# Altium schematic sheet Qadrature FM demodulator.SchDoc("Qadrature FM demodulator")
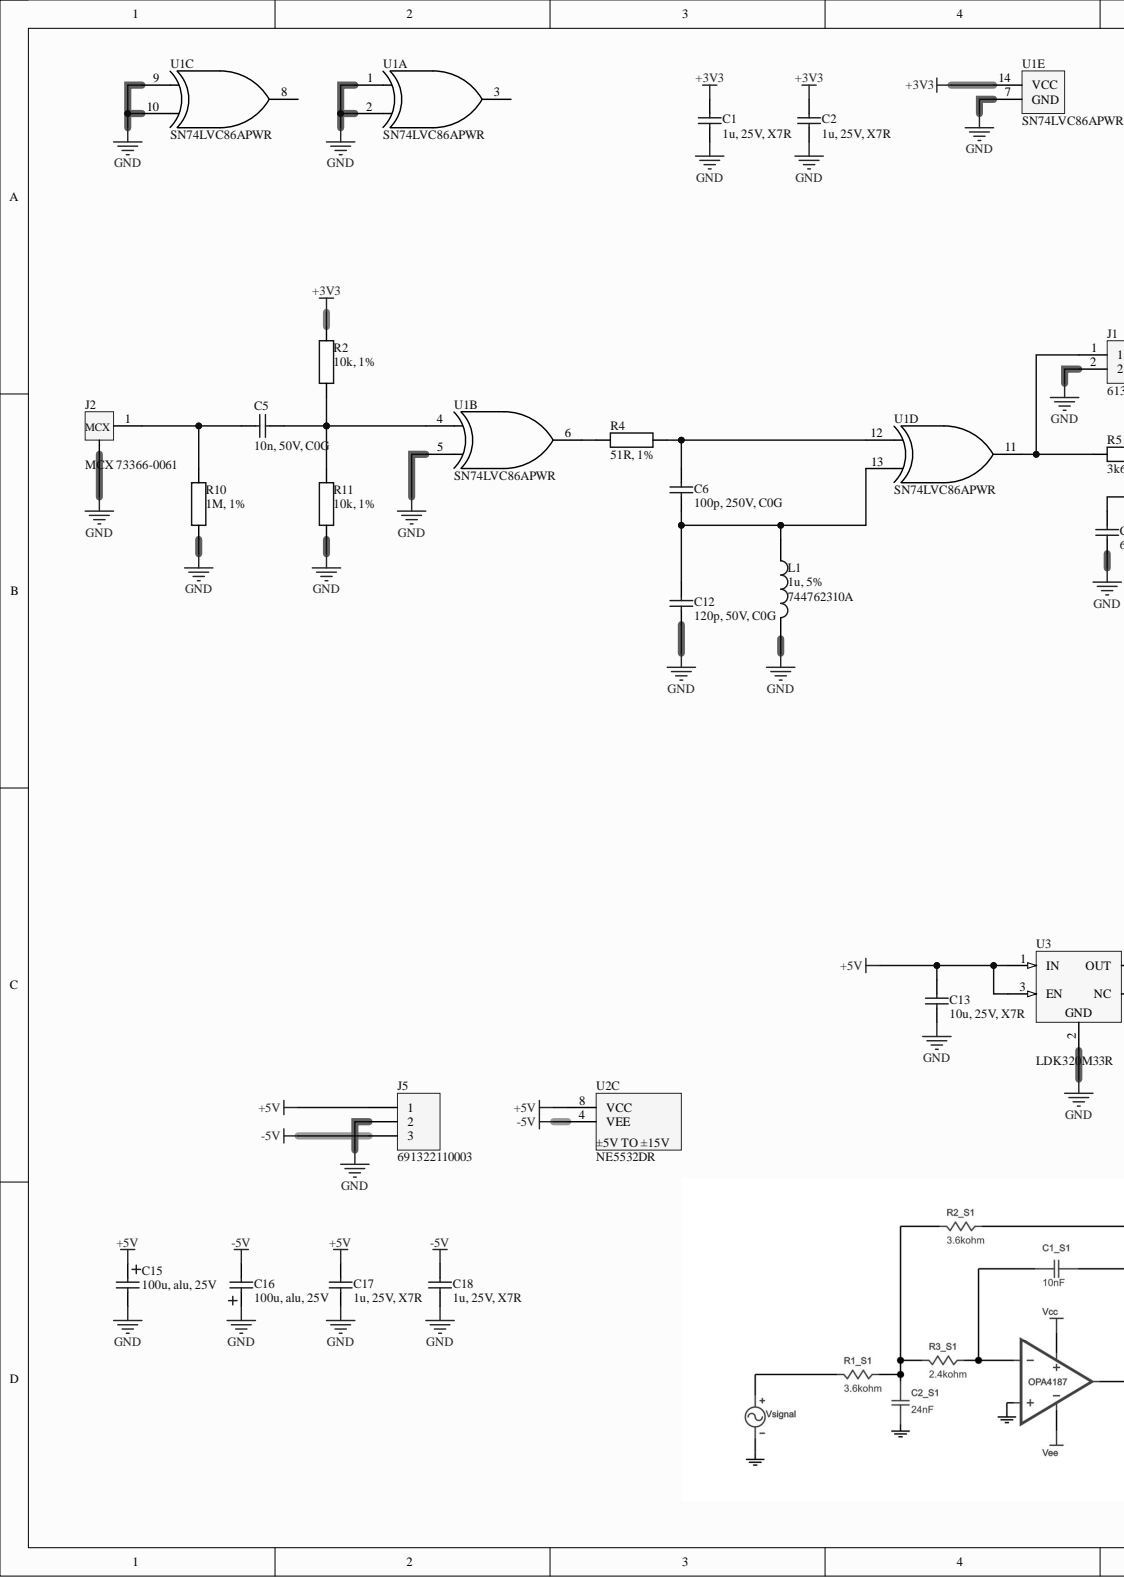
[diagram p0: Qadrature FM demodulator.SchDoc, left]
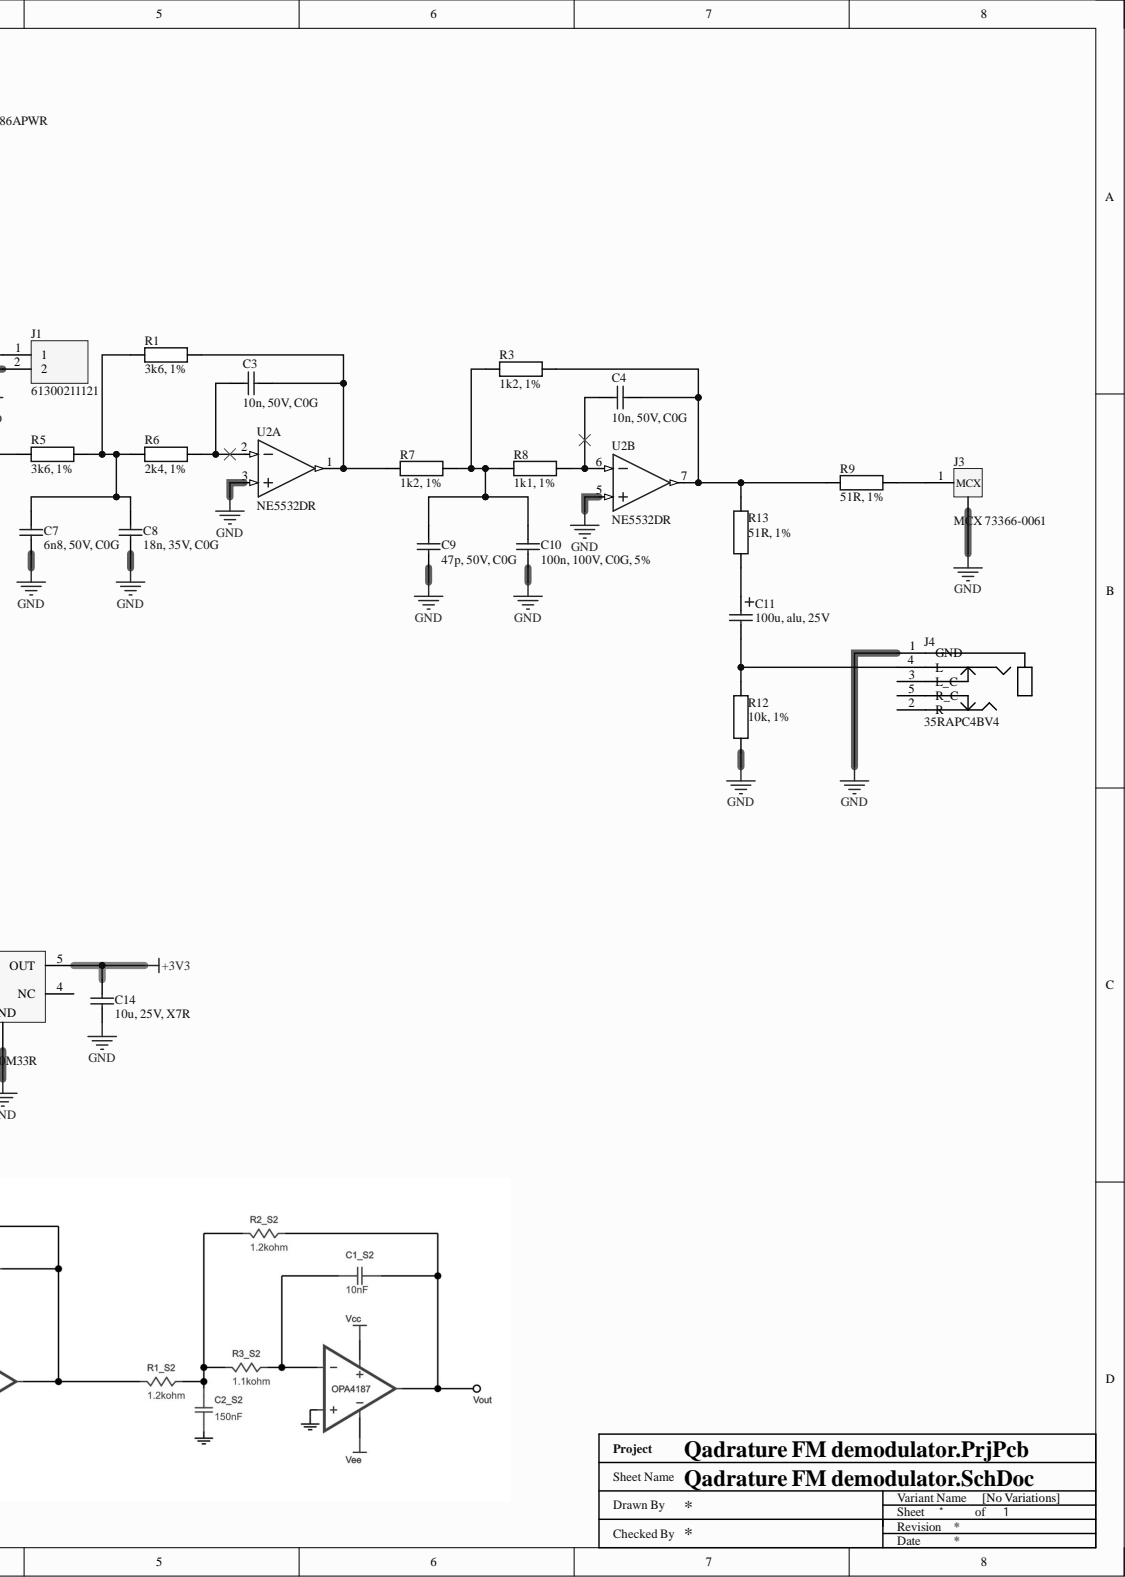
[diagram p1: Qadrature FM demodulator.SchDoc, right]

## Parts
C1  1u, 25V, X7R  [SMD0603]
C2  1u, 25V, X7R  Temperature Coefficient: X7R  [SMD0603]
C3  10n, 50V, C0G  [SMD0603]
C4  10n, 50V, C0G  [SMD0603]
C5  10n, 50V, C0G  [SMD0603]
C6  100p, 250V, C0G  [SMD0603]
C7  6n8, 50V, C0G  [SMD0603]
C8  18n, 35V, C0G  [SMD0603]
C9  47p, 50V, C0G  [SMD0805]
C10  100n, 100V, C0G, 5%  Dielectric: C0G  [SMD1206]
C11  100u, alu, 25V  [WCAP-ATLI_6.3x11x2.5]
C12  120p, 50V, C0G  [SMD0603]
C13  10u, 25V, X7R  [SMD1206]
C14  10u, 25V, X7R  [SMD1206]
C15  100u, alu, 25V  [WCAP-ATLI_6.3x11x2.5]
C16  100u, alu, 25V  Voltage Rating: 25V  [WCAP-ATLI_6.3x11x2.5]
C17  1u, 25V, X7R  Voltage - Rated: 25V  [SMD0603]
C18  1u, 25V, X7R  Temperature Coefficient: X7R  [SMD0603]
J1  61300211121  [Header 2 2.54mm]
J2  MCX 73366-0061  Connector Style: MCX  [73366-0061]
J3  MCX 73366-0061  Connector Style: MCX  [73366-0061]
J4  35RAPC4BV4  [35RAPC4BV4]
J5  691322110003  [691322110003]
L1  1u, 5%  Tolerance: 5%  [WE-KI_1008]
R1  3k6, 1%  Resistance Tolerance: 1%  [SMD0603 - R]
R2  10k, 1%  [SMD0603 - R]
R3  1k2, 1%  [SMD0603 - R]
R4  51R, 1%  [SMD0603 - R]
R5  3k6, 1%  Resistance Tolerance: 1%  [SMD0603 - R]
R6  2k4, 1%  [SMD0603 - R]
R7  1k2, 1%  [SMD0603 - R]
R8  1k1, 1%  [SMD0603 - R]
R9  51R, 1%  [SMD0603 - R]
R10  1M, 1%  [SMD0603 - R]
R11  10k, 1%  [SMD0603 - R]
R12  10k, 1%  [SMD0603 - R]
R13  51R, 1%  [SMD0603 - R]
U1  SN74LVC86APWR  [TSSOP14]  (parts A,B,C,D,E)
U2  NE5532DR  [SOIC08]  (parts A,B,C)
U3  LDK320M33R  [SOT23-5]

## Nets
+3V3: C1.2 C2.2 C14.2 R2.2 U1.14(VCC) U3.5(OUT)
+5V: C13.2 C15.1 C17.2 J5.1 U2.8(VCC) U3.1(IN) U3.3(EN)
-5V: C16.2 C18.2 J5.3 U2.4(VEE)
GND: C1.1 C2.1 C7.1 C8.1 C9.1 C10.1 C12.1 C13.1 C14.1 C15.2 C16.1 C17.1 C18.1 J1.2 J2.2 J3.2 J4.1(GND) J5.2 L1.2 R10.1 R11.1 R12.1 U1.1 U1.2 U1.5 U1.7(GND) U1.9 U1.10 U2.3 U2.5 U3.2
NetC3_1: C3.1 R6.2 U2.2
NetC3_2: C3.2 R1.2 R7.1 U2.1
NetC4_1: C4.1 R8.2 U2.6
NetC4_2: C4.2 R3.2 R9.1 R13.2 U2.7
NetC5_1: C5.1 J2.1 R10.2
NetC5_2: C5.2 R2.1 R11.2 U1.4
NetC6_1: C6.1 C12.2 L1.1 U1.13
NetC6_2: C6.2 R4.2 U1.12
NetC7_2: C7.2 C8.2 R1.1 R5.2 R6.1
NetC9_2: C9.2 C10.2 R3.1 R7.2 R8.1
NetC11_1: C11.1 R13.1
NetC11_2: C11.2 J4.4(L) R12.2
NetJ1_1: J1.1 R5.1 U1.11
NetJ3_1: J3.1 R9.2
NetR4_1: R4.1 U1.6

Not connected: J4 2(R) 3(L_C) 5(R_C); U1 3 8; U3 4(NC)

## Library data of the parts
C1 C2 C17 C18 = CGA3E1X7R1E105K080AC: CAP CER 1UF 25V X7R 0603; Manufacturer: TDK Corporation; Tolerance: ±10%; Applications: Automotive; Capacitance: 1u; Category: Capacitors; Component Kind: Standard; ComponentLink1Description: https://product.tdk.com/info/en/catalog/datasheets/mlcc_automotive_general_en.pdf; ComponentLink1URL: https://product.tdk.com/info/en/catalog/datasheets/mlcc_automotive_general_en.pdf; Failure Rate: -; Features: -; Height - Seated (Max): -; Lead Spacing: -; Lead Style: -; Library Name: SMD0603Cap.IntLib; Manufacturer Part Number: CGA3E1X7R1E105K080AC; Mounting Type: Surface Mount, MLCC; Operating Temperature: -55°C ~ 125°C; Package / Case: 0603 (1608 Metric); Packaging: Cut Tape (CT); Part Status: Active; Pricing 1: 1=0.2, 10=0.135, 100=0.0686, 500=0.04696, 1000=0.04154 (USD); Ratings: AEC-Q200; RoHS: RoHS Compliant; Size / Dimension: 0.063" L x 0.032" W (1.60mm x 0.80mm); Stock 1: 109509; Supplier 1: Mouser; Supplier 2: Farnell; Supplier 3: Digi-Key; Supplier Part Number 1: 810-CGA3E1X7R1E105K; Supplier Part Number 2: 2346962; Supplier Part Number 3: 445-6931-1-ND; Thickness (Max): 0.035" (0.90mm)
  C1: Temperature Coefficient: X7R; Voltage - Rated: 25V
  C2 C18: Voltage - Rated: 25V
  C17: Temperature Coefficient: X7R
C3-C5 = GRM1885C1H103JA01D: Multilayer Ceramic Capacitors MLCC - SMD/SMT 0603 50VDC 10000pF 5% C0G; Brand: Murata Electronics; Capacitance - nF: 10 nF; Class: Class 1; CNHTS: 8532241000; Dielectric: C0G (NP0); TARIC: 8532240000; Type: Chip Multilayer Ceramic Capacitor for General Purpose; Capacitance: 10n; Capacitance - pF: 10000 pF; Case Code - in: 0603; Case Code - mm: 1608; Category: Multilayer Ceramic Capacitors MLCC - SMD/SMT; Component Kind: Standard; ComponentLink1Description: http://www.mouser.com/datasheet/2/281/murata_03052018_GRM_Series_1-1310166.pdf; ComponentLink1URL: http://www.mouser.com/datasheet/2/281/murata_03052018_GRM_Series_1-1310166.pdf; Height: 0.8 mm; HTS Code: 8532240020; Length: 1.6 mm; Library Name: SMD0603Cap.IntLib; Manufacturer: Murata Electronics; Manufacturer Part Number: GRM1885C1H103JA01D; Maximum Operating Temperature: + 125 C; Minimum Operating Temperature: - 55 C; Minimum Order Quantity: 1; Package / Case: 0603 (1608 metric); Packaging: Cut Tape; Pricing 1: 1=0.183, 10=0.129, 100=0.065, 500=0.045, 1000=0.039, 4000=0.030, 8000=0.027, 24000=0.025 (EUR); Product: General Type MLCCs; Product Type: Ceramic Capacitors; Reeling: True; RoHS: RoHS Compliant; RoHS - Mouser: Y; Series: GRM; Standard Pack Qty: 4000; Stock 1: 217291; Subcategory: Capacitors; Supplier 1: Mouser; Supplier 2: Farnell; Supplier Part Number 1: 81-GRM1885C1H103JA1D; Supplier Part Number 2: 2456107; Termination: Standard; Termination Style: SMD/SMT; Tolerance: 5 %; Voltage Rating DC: 50 VDC; Width: 0.8 mm
C6 = 885342006003: CAP CER 100PF 250V C0G/NP0 0603; Manufacturer: Wurth Electronics Inc.; Notification: Current market demand for these product types have resulted in fluctuating and extending lead times. Lead times may differ.; Temperature Coefficient: C0G, NP0; Tolerance: ±5%; Applications: General Purpose; Capacitance: 100p; Category: Capacitors; Component Kind: Standard; ComponentLink1Description: https://katalog.we-online.de/pbs/datasheet/885342006003.pdf; ComponentLink1URL: https://katalog.we-online.de/pbs/datasheet/885342006003.pdf; Failure Rate: -; Features: -; Height - Seated (Max): -; Lead Spacing: -; Lead Style: -; Library Name: SMD0603Cap.IntLib; Manufacturer Part Number: 885342006003; Mounting Type: Surface Mount, MLCC; Operating Temperature: -55°C ~ 125°C; Package / Case: 0603 (1608 Metric); Packaging: Cut Tape (CT); Part Status: Active; Pricing 1: 1=0.1, 10=0.043, 100=0.0385, 500=0.03406 (USD); Ratings: -; RoHS: RoHS Compliant; Size / Dimension: 0.063" L x 0.031" W (1.60mm x 0.80mm); Stock 1: 43; Supplier 1: Digi-Key; Supplier 2: Farnell; Supplier 3: Mouser; Supplier Part Number 1: 732-12167-1-ND; Supplier Part Number 2: 2812519; Supplier Part Number 3: 710-885342006003; Thickness (Max): 0.034" (0.87mm); Voltage - Rated: 250V
C7 = GRM1885C1H682JA01D: Multilayer Ceramic Capacitors MLCC - SMD/SMT 0603 6,800pF 50volts C0G +/-5%; Manufacturer: Murata; Tolerance: 5%; Capacitance: 6n8; Case Code (Imperial): 0603; Case Code (Metric): 1608; Case/Package: 0603; Component Kind: Standard; ComponentLink1Description: https://datasheet.ciiva.com/29537/partnumbering-e-01-29537912.pdf?src-supplier=Digi-Key; ComponentLink1URL: https://datasheet.ciiva.com/29537/partnumbering-e-01-29537912.pdf?src-supplier=Digi-Key; Depth: 0.8mm; Dielectric: C0G; Height: 0.8mm; Lead Free: Lead Free; Length: 1.6mm; Library Name: SMD0603Cap.IntLib; Manufacturer Part Number: GRM1885C1H682JA01D; Max Operating Temperature: 125°C; Min Operating Temperature: -55°C; Mount: Surface Mount; Package Quantity: 4000; Packaging: Tape and Reel; Pricing 1: 1 = 0.20000, 10 = 0.13500, 50 = 0.13500, 100 = 0.06800, 1000 = 0.04100, 10000 = 0.02900 (USD); RoHS: Yes; Stock 1: 117152; Supplier 1: Mouser; Supplier 2: Digi-Key; Supplier 3: Arrow; Supplier Part Number 1: 81-GRM1885C1H682JA1D; Supplier Part Number 2: 490-11477-1-ND; Supplier Part Number 3: GRM1885C1H682JA01D; Termination: SMD/SMT; Thickness: 0.035inch; Voltage Rating: 50V; Voltage Rating (DC): 50V; Width: 0.031inch
C8 = C1608C0G1V183J080AC: Cap Ceramic 0.018uF 35V C0G 5% Pad SMD 0603 125C T/R; Manufacturer: TDK; Capacitance: 18n; Case Code (Imperial): 0603; Case Code (Metric): 1608; Case/Package: 0603; Category: Ceramic Capacitors; Component Kind: Standard; ComponentLink1Description: https://product.tdk.com/info/en/catalog/spec/mlccspec_commercial_general_midvoltage_en.pdf?src-supplier=Digi-Key; ComponentLink1URL: https://product.tdk.com/info/en/catalog/spec/mlccspec_commercial_general_midvoltage_en.pdf?src-supplier=Digi-Key; Dielectric Material: Ceramic; Lead Free: Lead Free; Length: 0.063inch; Library Name: SMD0603Cap.IntLib; Manufacturer Part Number: C1608C0G1V183J080AC; Max Operating Temperature: 125°C; Min Operating Temperature: -55°C; Mount: Surface Mount; Packaging: Tape and Reel; Pricing 1: 1=0.37000, 10=0.16800, 50=0.16800, 100=0.14300, 1000=0.08800, 10000=0.06600 (USD); RoHS: Yes; RoHS Compliant: Yes; Stock 1: 3185; Supplier 1: Mouser; Supplier 2: Farnell; Supplier 3: Digi-Key; Supplier 4: Newark; Supplier Part Number 1: 810-C1608C0G1V183JAC; Supplier Part Number 2: 3416084; Supplier Part Number 3: 445-174558-1-ND; Supplier Part Number 4: 71AH3230; Thickness: 0.035inch; Tolerance: 5%; Voltage Rating: 35V; Voltage Rating (DC): 35V; Width: 0.031inch
C9 = MC0805N470J500CT: MULTICOMP - MC0805N470J500CT - CAP, MLCC, C0G/NP0, 47PF, 50V, 0805; Capacitance: 47; Capacitance Tolerance: ± 5%; Ceramic Capacitor Case: 0805 [2012 Metric]; Product Range: MC Series; SVHC: No SVHC (07-Jul-2017)<!--FANDB--><div class="features-and-benefits-container"><div class="features-and-benefits-desc-container"><span class="features-and-benefits-container-desc">SMD Multilayer Ceramic Capacitor, 0805 [2012 Metric], 47 pF, 50 V, ± 5%, C0G / NP0, MC Series</span></div><div class="features-and-benefits-description"><span class="features-benefits-value">The Multicomp MC series is general purpose MLCC capacitors with C0G (NP0) dielectric material which provides high electrical precision, stability and reliability. This MLCC capacitor consists of a conducting material and electrodes with high density and high efficiency ceramic condensers.</span></div><div class="features-and-benefits-list"><ul class="features-and-benefits-list-list"><li class="features-and-benefits-list-item">Small size with higher capacitance values</li><li class="features-and-benefits-list-item">0201, 0402, 0603, 0805, 1206, 1210, 1812 case sizes available</li><li class="features-and-benefits-list-item">Capacitance value ranging from 0.1pF to 0.1?F</li><li class="features-and-benefits-list-item">Capacitor with lead free termination (pure Tin)</li><li class="features-and-benefits-list-item">High electrical precision, stability and reliability</li><li class="features-and-benefits-list-item">Rated voltage of 10V, 16V, 25V, 50V, 100V</li><li class="features-and-benefits-list-item">Operating temperature of -55°C to +125°C</li><li class="features-and-benefits-list-item">Temperature coefficient (TCR) of ±30; Voltage Rating: 50; Automotive Qualification Standard: -; Component Kind: Standard; ComponentLink1Description: http://www.farnell.com/datasheets/1901289.pdf; ComponentLink1URL: http://www.farnell.com/datasheets/1901289.pdf; Dielectric Characteristic: C0G / NP0; Library Name: SMD0805Cap.IntLib; Manufacturer: MULTICOMP; Manufacturer Part Number: MC0805N470J500CT; MSL: -; Operating Temperature Max: 125; Operating Temperature Min: -55; Packaging: Cut Tape; Pricing 1: 10=0.0144, 100=0.0117, 500=0.0083, 1000=0.0072, 2000=0.0057 (GBP); RoHS: YES; Stock 1: 26554; Supplier 1: Farnell; Supplier Part Number 1: 1759199
C10 = GRM31C5C2A104JA01L: CAP CER 0.1UF 100V C0G/NP0 1206; Manufacturer: Murata Electronics North America; Notification: Current market demand for these product types have resulted in fluctuating and extending lead times. Lead times may differ.; Temperature Coefficient: C0G, NP0; Applications: General Purpose; Capacitance: 100n; Category: Capacitors; Component Kind: Standard; ComponentLink1Description: http://search.murata.co.jp/Ceramy/image/img/A01X/G101/ENG/GRM31C5C2A104JA01-01.pdf; ComponentLink1URL: http://search.murata.co.jp/Ceramy/image/img/A01X/G101/ENG/GRM31C5C2A104JA01-01.pdf; Failure Rate: -; Features: -; Height - Seated (Max): -; Lead Spacing: -; Lead Style: -; Library Name: SMD1206Cap.IntLib; Manufacturer Part Number: GRM31C5C2A104JA01L; Mounting Type: Surface Mount, MLCC; Operating Temperature: -55°C ~ 125°C; Package / Case: 1206 (3216 Metric); Packaging: Cut Tape (CT); Part Status: Active; Pricing 1: 1=0.82, 10=0.581, 100=0.3823, 500=0.2832, 1000=0.24072 (USD); Ratings: -; RoHS: RoHS Compliant; Size / Dimension: 0.126" L x 0.063" W (3.20mm x 1.60mm); Stock 1: 1640; Supplier 1: Mouser; Supplier 2: Digi-Key; Supplier Part Number 1: 81-GRM31C5C2A104JA1L; Supplier Part Number 2: 490-16315-1-ND; Thickness (Max): 0.071" (1.80mm); Tolerance: ±5%; Voltage - Rated: 100V
C11 C15 C16 = 860010473007: CAP 100 UF 20% 25 V; Manufacturer: Wurth Electronics; Capacitance: 100uF; Life (Hours): 2000Hour; Material: Aluminium; Polarity: Polar; Ripple Current: 209mA; Case/Package: Radial; Category: Aluminum Electrolytic Capacitors; Component Kind: Standard; ComponentLink1Description: https://datasheet.ciiva.com/48693/001280151-da-01-en-wcap-atg8-alu-elec-caps-860010473007-48693591.pdf?src-supplier=Conrad; ComponentLink1URL: https://datasheet.ciiva.com/48693/001280151-da-01-en-wcap-atg8-alu-elec-caps-860010473007-48693591.pdf?src-supplier=Conrad; Diameter: 6.3mm; Height: 11mm; Height - Seated (Max): 0.492inch; Lead Pitch: 0.098inch; Library Name: Capacitors.IntLib; Manufacturer Part Number: 860010473007; Max Operating Temperature: 85°C; Min Operating Temperature: -40°C; Mount: Through Hole; Package Quantity: 1500; Packaging: Tape and Reel; Pricing 1: 1=0.14000, 10=0.13400, 25=0.13400, 50=0.11200, 100=0.09800, 250=0.08700 (USD); REACH SVHC: Unknown; RoHS: Yes; Stock 1: 1494; Supplier 1: Mouser; Supplier 2: Farnell; Supplier 3: Digi-Key; Supplier Part Number 1: 710-860010473007; Supplier Part Number 2: 2465675; Supplier Part Number 3: 732-8630-1-ND; Tolerance: 20%; Voltage Rating (DC): 25V
  C11 C15: Voltage Rating: 25V
C12 = CC0603JRNPO9BN121: Surface Mount Ceramic Multilayer Capacitor 120pF 5% C0G 50V 0603 Paper T/R; Manufacturer: Yageo; Insulation Resistance: 100GR; Capacitance: 120pF; Case Code (Imperial): 0603; Case Code (Metric): 1608; Case/Package: 0603; Category: Ceramic Capacitors; Component Kind: Standard; ComponentLink1Description: https://datasheet.ciiva.com/26862/getdatasheetpartid-137962-26862760.pdf?src-supplier=Verical; ComponentLink1URL: https://datasheet.ciiva.com/26862/getdatasheetpartid-137962-26862760.pdf?src-supplier=Verical; ComponentLink2Description: https://datasheet.ciiva.com/144/mlccorderingcode-144699.pdf?src-supplier=Digi-Key; ComponentLink2URL: https://datasheet.ciiva.com/144/mlccorderingcode-144699.pdf?src-supplier=Digi-Key; ComponentLink3Description: https://datasheet.ciiva.com/8033/1443161-8033719.pdf?src-supplier=Element14; ComponentLink3URL: https://datasheet.ciiva.com/8033/1443161-8033719.pdf?src-supplier=Element14; ComponentLink4Description: https://datasheet.ciiva.com/17437/upy-gp-np0-16v-to-50v-8-17437015.pdf?src-supplier=Avnet+Europe; ComponentLink4URL: https://datasheet.ciiva.com/17437/upy-gp-np0-16v-to-50v-8-17437015.pdf?src-supplier=Avnet+Europe; ComponentLink5Description: https://datasheet.ciiva.com/8033/1443161-8033720.pdf?src-supplier=Element14; ComponentLink5URL: https://datasheet.ciiva.com/8033/1443161-8033720.pdf?src-supplier=Element14; ComponentLink6Description: https://datasheet.ciiva.com/144/mlccorderingcode-144697.pdf?src-supplier=Digi-Key; ComponentLink6URL: https://datasheet.ciiva.com/144/mlccorderingcode-144697.pdf?src-supplier=Digi-Key; ComponentLink7Description: https://datasheet.ciiva.com/11465/upy-gp-np0-16v-to-50v-8-11465007.pdf?src-supplier=Avnet; ComponentLink7URL: https://datasheet.ciiva.com/11465/upy-gp-np0-16v-to-50v-8-11465007.pdf?src-supplier=Avnet; ComponentLink8Description: https://datasheet.ciiva.com/144/mlccorderingcode-144698.pdf?src-supplier=Digi-Key; ComponentLink8URL: https://datasheet.ciiva.com/144/mlccorderingcode-144698.pdf?src-supplier=Digi-Key; ComponentLink9Description: https://datasheet.ciiva.com/15381/upy-gp-np0-16v-to-50v-8-15381898.pdf?src-supplier=Mouser; ComponentLink9URL: https://datasheet.ciiva.com/15381/upy-gp-np0-16v-to-50v-8-15381898.pdf?src-supplier=Mouser; Depth: 0.8mm; Dielectric: C0G; Dielectric Material: Ceramic; Height: 0.8mm; Lead Free: Lead Free; Length: 1.6mm; Library Name: 0603Capacitors.SchLib; Manufacturer Part Number: CC0603JRNPO9BN121; Max Operating Temperature: 125°C; Min Operating Temperature: -55°C; Mount: Surface Mount; Package Quantity: 1; Packaging: Tape and Reel; Pricing 1: 1=0.10000, 10=0.06400, 50=0.06400, 100=0.02900, 1000=0.01700, 10000=0.01100 (USD); Radiation Hardening: No; REACH SVHC: Unknown; Resistor Type: Ceramic; RoHS: Yes; RoHS Compliant: Yes; Series: CC; Stock 1: 20714; Supplier 1: Mouser; Supplier 2: Farnell; Supplier 3: Digi-Key; Supplier Part Number 1: 603-CC603JRNPO9BN121; Supplier Part Number 2: 722091; Supplier Part Number 3: 311-1070-1-ND; Termination: SMD/SMT; Thickness: 0.035inch; Tolerance: 5%; Voltage Rating: 50V; Voltage Rating (DC): 50V; Width: 0.031inch
C13 C14 = GRM31CR71E106KA12L: MURATA - GRM31CR71E106KA12L - CAP, MLCC, X7R, 10UF, 25V, 1206; Capacitance: 10u; Component Kind: Standard; ComponentLink1Description: http://www.farnell.com/datasheets/2048746.pdf; ComponentLink1URL: http://www.farnell.com/datasheets/2048746.pdf; Dielectric: X7R; Library Name: SMD1206Cap.IntLib; Manufacturer: MURATA; Manufacturer Part Number: GRM31CR71E106KA12L; Pricing 1: 5=0.278, 50=0.185, 250=0.139, 500=0.134, 1000=0.128 (GBP); RoHS: YES; Stock 1: 8848; Supplier 1: Mouser; Supplier 2: Farnell; Supplier 3: Digi-Key; Supplier Part Number 1: 81-GRM31CR71E106KA12; Supplier Part Number 2: 1828837; Supplier Part Number 3: 490-6518-1-ND
J1 = 61300211121: CONN HEADER 2 POS 2.54; Manufacturer: Wurth Electronics Inc.; Contact Length - Mating: 0.236" (6.00mm); Contact Length - Post: 0.118" (3.00mm); Current Rating: 3A; Material Flammability Rating: UL94 V-0; Number of Positions: 2; Number of Positions Loaded: All; Overall Contact Length: 0.454" (11.54mm); Pitch - Mating: 0.100" (2.54mm); Shrouding: Unshrouded; Style: Board to Board or Cable; Voltage Rating: 250V; Applications: -; Category: Connectors, Interconnects; Component Kind: Standard; ComponentLink1Description: https://katalog.we-online.de/em/datasheet/6130xx11121.pdf; ComponentLink1URL: https://katalog.we-online.de/em/datasheet/6130xx11121.pdf; Connector Type: Header; Contact Finish - Mating: Gold; Contact Finish - Post: -; Contact Finish Thickness - Mating: -; Contact Finish Thickness - Post: -; Contact Material: Copper Alloy; Contact Shape: Square; Contact Type: Male Pin; Fastening Type: Push-Pull; Features: -; Ingress Protection: -; Insulation Color: Black; Insulation Height: 0.100" (2.54mm); Insulation Material: Polybutylene Terephthalate (PBT); Library Name: Connectors.IntLib; Manufacturer Part Number: 61300211121; Mated Stacking Heights: -; Mounting Type: Through Hole; Number of Rows: 1; Operating Temperature: -40°C ~ 125°C; Packaging: Bulk; Part Status: Active; Pricing 1: 1=0.13, 10=0.106, 25=0.088, 50=0.074, 100=0.067, 1000=0.061 (USD); RoHS: RoHS Compliant; Row Spacing - Mating: -; Stock 1: 164911; Supplier 1: Mouser; Supplier 2: Farnell; Supplier 3: Digi-Key; Supplier Part Number 1: 710-61300211121; Supplier Part Number 2: 2356153; Supplier Part Number 3: 732-5315-ND; Termination: Solder
J2 J3 = 73366-0061: CONN MCX JACK STR 50 OHM PCB; Manufacturer: Molex, LLC; Manufacturer Part Number: 0733660061; Body Finish: Gold; Body Material: Brass; Center Contact Material: Beryllium Copper; Connector Type: Jack, Female Socket; Contact Termination: Solder; Dielectric Material: Polytetrafluoroethylene (PTFE); Impedance: 50 Ohm; Mating Cycles: 500; Cable Group: -; Category: Connectors, Interconnects; Center Contact Plating: Gold; Component Kind: Standard; ComponentLink1Description: https://www.molex.com/pdm_docs/sd/733660061_sd.pdf; ComponentLink1URL: https://www.molex.com/pdm_docs/sd/733660061_sd.pdf; Fastening Type: Snap-On; Features: -; Housing Color: Gold; Includes: -; Ingress Protection: -; Insertion Loss: -; Library Name: Connectors.IntLib; Mounting Feature: -; Mounting Type: Through Hole; Operating Temperature: -55°C ~ 155°C; Packaging: Tray; Part Status: Active; Pricing 1: 1=1.95, 10=1.765, 25=1.6572, 50=1.5854, 100=1.5133, 250=1.36916, 500=1.26106, 1000=1.0809, 2500=1.00884 (USD); RoHS: RoHS Compliant; Shield Termination: Solder; Stock 1: 39394; Supplier 1: Mouser; Supplier 2: Digi-Key; Supplier 3: Farnell; Supplier Part Number 1: 538-73366-0061; Supplier Part Number 2: WM5541-ND; Supplier Part Number 3: 1909245
J4 = 35RAPC4BV4: SWITCHCRAFT - 35RAPC4BV4 - CONNECTOR, RCA/PHONO, JACK, 3WAY; Connector Body Material: Plastic Body; Connector Colour: Black; Connector Mounting: PCB Mount; Contact Termination: Solder<!--FANDB--><div class="features-and-benefits-container"><div class="features-and-benefits-description"><span class="features-benefits-value">The 35RAPC4BV4 is a 3.5mm Mono and Stereo PC Board Vertical-mount Jack with black PBT+15% glass filled housing, nickel-plated brass bushing, tin-plated phosphor bronze springs and a clear polycarbonate cover. The compact design and an easy of installation onto a PCB make this an ideal solution.</span></div><div class="features-and-benefits-list"><ul class="features-and-benefits-list-list"><li class="features-and-benefits-list-item">5000 cycles Life</li><li class="features-and-benefits-list-item">50; No. of Contacts: 3; Pin Diameter: 3.5; SVHC: No SVHC (15-Jun-2015); Component Kind: Standard; ComponentLink1Description: http://www.farnell.com/datasheets/921436.pdf; ComponentLink1URL: http://www.farnell.com/datasheets/921436.pdf; Contact Plating: Tin Plated Contacts; Gender: Jack; Library Name: Connectors.IntLib; Manufacturer: SWITCHCRAFT; Manufacturer Part Number: 35RAPC4BV4; Pricing 1: 1=1.28, 25=1.11, 50=1.06, 100=1.01, 250=0.975, 500=0.939 (GBP); Product Range: -; RoHS: YES; Stock 1: 216; Supplier 1: Farnell; Supplier 2: Digi-Key; Supplier Part Number 1: 2293877; Supplier Part Number 2: SC1461-ND
J5 = 691322110003: WR-TBL Serie 3221 - 3.50 mm Horizontal PCB Header, 03p; Pitch: 3.5 mm; Component Kind: Standard; ComponentLink1Description: Manufacturer; ComponentLink1URL: http://www.we-online.de; ComponentLink2Description: Datasheet; ComponentLink2URL: http://katalog.we-online.de/em/datasheet/6913221100xx.pdf; Library Name: Connectors.IntLib; Part Number: 691322110003; Pin Number: 03p; Published Date: Jan 2017; Supplier 1: Digi-Key; Supplier 2: Farnell; Supplier Part Number 1: 732-2770-ND; Supplier Part Number 2: 1841316; Publisher: Wurth Elektronik
L1 = 744762310A: Fixed Ind 1UH 120MA 3.3 Ohm SMD; Manufacturer: Wurth Electronics; Core Material: Ceramic; DC Resistance (DCR): 3.3R; Q Factor: 35; Self Resonant Frequency: 310MHz; Shielding: Unshielded; Test Frequency: 25MHz; Case Code (Imperial): 1008; Case Code (Metric): 2520; Case/Package: 1008; Category: Fixed Inductors; Component Kind: Standard; ComponentLink1Description: https://datasheet.ciiva.com/1049/744762310a-1049271.pdf?src-supplier=Digi-Key; ComponentLink1URL: https://datasheet.ciiva.com/1049/744762310a-1049271.pdf?src-supplier=Digi-Key; ComponentLink2Description: https://datasheet.ciiva.com/4978/744762310a-69476-4978731.pdf?src-supplier=Mouser; ComponentLink2URL: https://datasheet.ciiva.com/4978/744762310a-69476-4978731.pdf?src-supplier=Mouser; ComponentLink3Description: https://datasheet.ciiva.com/1049/744762310a-1049272.pdf?src-supplier=Digi-Key; ComponentLink3URL: https://datasheet.ciiva.com/1049/744762310a-1049272.pdf?src-supplier=Digi-Key; ComponentLink4Description: https://datasheet.ciiva.com/1049/744762310a-1049273.pdf?src-supplier=Digi-Key; ComponentLink4URL: https://datasheet.ciiva.com/1049/744762310a-1049273.pdf?src-supplier=Digi-Key; Current Rating: 120mA; Height: 0.039inch; Height - Seated (Max): 0.075inch; Inductance: 1uH; Length: 0.102inch; Library Name: Inductors.SchLib; Manufacturer Part Number: 744762310A; Max DC Current: 120mA; Max Operating Temperature: 125°C; Min Operating Temperature: -40°C; Mount: Surface Mount; Package Quantity: 2000; Packaging: Tape and Reel; Pricing 1: 1=0.41000, 10=0.38200, 50=0.35700, 100=0.33000, 1000=0.30300, 10000=0.25600 (USD); Resistor Type: Wirewound,Ceramic; RoHS: Yes; RoHS Compliant: Yes; Series: WE-KI; Stock 1: 1904; Supplier 1: Mouser; Supplier 2: Farnell; Supplier 3: Digi-Key; Supplier Part Number 1: 710-744762310A; Supplier Part Number 2: 3517053; Supplier Part Number 3: 732-1832-1-ND; Termination: SMD/SMT; Width: 0.083inch
R1 R5 = RC0603FR-073K6L: RES SMD 3.6K OHM 1% 1/10W 0603; Manufacturer: Yageo; Max Power Dissipation: 100mW; Temperature Coefficient: 100ppm/°C; Voltage Rating: 50V; Working Voltage: 75V; Case Code (Imperial): 0603; Case Code (Metric): 1608; Case/Package: 0603; Category: Chip SMD Resistors; Component Kind: Standard; ComponentLink1Description: https://datasheet.ciiva.com/2455/pyu-r-int-thick-7-2455116.pdf?src-supplier=Digi-Key; ComponentLink1URL: https://datasheet.ciiva.com/2455/pyu-r-int-thick-7-2455116.pdf?src-supplier=Digi-Key; ComponentLink2Description: https://datasheet.ciiva.com/2455/pyu-r-int-thick-7-2455117.pdf?src-supplier=Digi-Key; ComponentLink2URL: https://datasheet.ciiva.com/2455/pyu-r-int-thick-7-2455117.pdf?src-supplier=Digi-Key; ComponentLink3Description: https://datasheet.ciiva.com/2455/pyu-r-int-thick-7-2455118.pdf?src-supplier=Digi-Key; ComponentLink3URL: https://datasheet.ciiva.com/2455/pyu-r-int-thick-7-2455118.pdf?src-supplier=Digi-Key; Features: Moisture Resistant; Height: 0.022inch; Lead Free: Lead Free; Length: 0.063inch; Library Name: SMD0603Res.IntLib; Manufacturer Part Number: RC0603FR-073K6L; Max Operating Temperature: 155°C; Min Operating Temperature: -55°C; Number of Pins: 2; Number of Terminations: 2; Package Quantity: 1; Packaging: Tape and Reel; Power Rating: 100mW; Pricing 1: 1=0,10000, 10=0,01800, 50=0,01800, 100=0,00600, 1000=0,00500, 10000=0,00300 (USD); REACH SVHC: Unknown; Resistance: 3k6; Resistor Type: Thick Film; RoHS: Yes; RoHS Compliant: Yes; Series: RC; Stock 1: 130231; Supplier 1: Mouser; Supplier 2: Farnell; Supplier 3: Digi-Key; Supplier Part Number 1: 603-RC0603FR-073K6L; Supplier Part Number 2: 3495832; Supplier Part Number 3: 311-3.60KHRCT-ND; Technology: METAL OXIDE FILM; Termination: SMD/SMT; Tolerance: 1%; Voltage Rating (DC): 50V; Width: 0.8mm
R2 R11 R12 = RC0603FR-0710KL: YAGEO (PHYCOMP) - RC0603FR-0710KL - RES, THICK FILM, 10K, 1%, 0.1W, 0603; Power Rating: 100; Product Range: RC Series; Resistor Case Style: 0603 [1608 Metric]; SVHC: No SVHC (20-Jun-2016); Temperature Coefficient: ± 100; Voltage Rating: 50; Automotive Qualification Standard: -; Component Kind: Standard; ComponentLink1Description: http://past.yageo.com/pdf/PYu-R_Packing_6.pdf; ComponentLink1URL: http://past.yageo.com/pdf/PYu-R_Packing_6.pdf; ComponentLink2Description: http://www.farnell.com/datasheets/2167052.pdf; ComponentLink2URL: http://www.farnell.com/datasheets/2167052.pdf; Library Name: SMD0603Res.IntLib; Manufacturer: YAGEO (PHYCOMP); Manufacturer Part Number: RC0603FR-0710KL; MSL: MSL 1 - Unlimited<!--FANDB--><div class="features-and-benefits-container"><div class="features-and-benefits-desc-container"><span class="features-and-benefits-container-desc">SMD Chip Resistor, Thick Film, 10 kohm, 50 V, 0603 [1608 Metric], 100 mW, ± 1; Packaging: Tape & Reel; Pricing 1: 5000=0.0025, 25000=0.0014, 50000=0.0013 (GBP); Resistance: 10k; Resistance Tolerance: ± 1%; Resistor Element Material: Thick Film; RoHS: YES; Stock 1: 9995; Supplier 1: Mouser; Supplier 2: Farnell; Supplier 3: Digi-Key; Supplier Part Number 1: 603-RC0603FR-0710KL; Supplier Part Number 2: 2421850; Supplier Part Number 3: 311-10.0KHRCT-ND
R3 R7 = WR06X1201FTL: WALSIN - WR06X1201FTL - RESISTOR, THICK FILM, 1K2, 1%, 75V, 0603; Power Rating: 100; Product Range: WR06 Series; Resistor Case Style: 0603 [1608 Metric]; Temperature Coefficient: ± 100; Voltage Rating: 75; Automotive Qualification Standard: -; Component Kind: Standard; ComponentLink1Description: http://www.farnell.com/datasheets/1960319.pdf; ComponentLink1URL: http://www.farnell.com/datasheets/1960319.pdf; Library Name: SMD0603Res.IntLib; Manufacturer: WALSIN; Manufacturer Part Number: WR06X1201FTL; MSL: MSL 2 - 1 year<!--FANDB--><div class="features-and-benefits-container"><div class="features-and-benefits-desc-container"><span class="features-and-benefits-container-desc">SMD Chip Resistor, 1.2 kohm, 75 V, 0603 [1608 Metric], 100 mW, ± 1%, WR06 Series</span></div><div class="features-and-benefits-description"><span class="features-benefits-value">The WR06 series resistors from Walsin are general purpose thick film chip resistors. These resistors are constructed in a high grade ceramic body (aluminium oxide).</span></div><div class="features-and-benefits-list"><ul class="features-and-benefits-list-list"><li class="features-and-benefits-list-item">Resistance range includes E24 and E96 decade values from 1ohm to 10Mohm</li><li class="features-and-benefits-list-item">EIA 0603 case size</li><li class="features-and-benefits-list-item">Available in ?±100ppm/°C and -200ppm/°C to +400ppm/°C TCR values</li><li class="features-and-benefits-list-item">Available in ±1% and ±5% tolerance</li><li class="features-and-benefits-list-item">High reliability and stability</li><li class="features-and-benefits-list-item">Higher component and equipment reliability</li><li class="features-and-benefits-list-item">Lower assembly costs</li><li class="features-and-benefits-list-item">Reduced size of final equipment</li><li class="features-and-benefits-list-item">Continuous working voltage is 75V and rated power is 100; Packaging: Cut Tape; Pricing 1: 10=0.0134, 100=0.0045, 500=0.0027, 1000=0.0019, 2500=0.0015 (GBP); Resistance: 1k2; Resistance Tolerance: ± 1%; Resistor Element Material: Thick Film; RoHS: YES; Stock 1: 8072; Supplier 1: Farnell; Supplier 2: Newark; Supplier Part Number 1: 2502404; Supplier Part Number 2: 58Y7264
R4 R9 R13 = CRCW060351R0FKEA: RES SMD 51 OHM 1% 1/10W 0603; Manufacturer: Vishay Dale; Power (Watts): 0.1W, 1/10W; Temperature Coefficient: ±100ppm/°C; Category: Resistors; Component Kind: Standard; ComponentLink1Description: http://www.vishay.com/docs/20035/dcrcwe3.pdf; ComponentLink1URL: http://www.vishay.com/docs/20035/dcrcwe3.pdf; Composition: Thick Film; Failure Rate: -; Features: Automotive AEC-Q200; Height - Seated (Max): 0.020" (0.50mm); Library Name: SMD0603Res.IntLib; Manufacturer Part Number: CRCW060351R0FKEA; Operating Temperature: -55°C ~ 155°C; Package / Case: 0603 (1608 Metric); Packaging: Cut Tape (CT); Part Status: Active; Pricing 1: 1=0.1, 10=0.042, 100=0.017, 1000=0.00764, 2500=0.00663 (USD); Resistance: 51R; RoHS: RoHS Compliant; Size / Dimension: 0.063" L x 0.033" W (1.60mm x 0.85mm); Stock 1: 21691; Supplier 1: Mouser; Supplier 2: Farnell; Supplier 3: Digi-Key; Supplier Device Package: 0603; Supplier Part Number 1: 71-CRCW0603-51-E3; Supplier Part Number 2: 1652889; Supplier Part Number 3: 541-51.0HCT-ND; Tolerance: ±1%
R6 = RC0603FR-072K4L: RES SMD 2.4K OHM 1% 1/10W 0603; Manufacturer: Yageo; Power (Watts): 0.1W, 1/10W; Temperature Coefficient: ±100ppm/°C; Category: Resistors; Component Kind: Standard; ComponentLink1Description: http://www.yageo.com/documents/recent/PYu-RC_Group_51_RoHS_L_8.pdf; ComponentLink1URL: http://www.yageo.com/documents/recent/PYu-RC_Group_51_RoHS_L_8.pdf; Composition: Thick Film; Failure Rate: -; Features: Moisture Resistant; Height - Seated (Max): 0.022" (0.55mm); Library Name: SMD0603Res.IntLib; Manufacturer Part Number: RC0603FR-072K4L; Operating Temperature: -55°C ~ 155°C; Package / Case: 0603 (1608 Metric); Packaging: Cut Tape (CT); Part Status: Active; Pricing 1: 1=0.1, 10=0.015, 100=0.006, 1000=0.00268, 2500=0.00233 (USD); Resistance: 2k4; RoHS: RoHS Compliant; Size / Dimension: 0.063" L x 0.032" W (1.60mm x 0.80mm); Stock 1: 577810; Supplier 1: Mouser; Supplier 2: Farnell; Supplier 3: Digi-Key; Supplier Device Package: 0603; Supplier Part Number 1: 603-RC0603FR-072K4L; Supplier Part Number 2: 1799329; Supplier Part Number 3: 311-2.40KHRCT-ND; Tolerance: ±1%
R8 = MCWR06X1101FTL: MULTICOMP - MCWR06X1101FTL - RES, THICK FILM, 1K1, 1%, 0.1W, 0603; Power Rating: 100; Product Range: MCWR Series; Resistor Case Style: 0603 [1608 Metric]; SVHC: No SVHC (07-Jul-2017)<!--FANDB--><div class="features-and-benefits-container"><div class="features-and-benefits-desc-container"><span class="features-and-benefits-container-desc">SMD Chip Resistor, Thick Film, 1.1 kohm, 50 V, 0603 [1608 Metric], 100 mW, ± 1; Temperature Coefficient: ± 100; Voltage Rating: 50; Automotive Qualification Standard: -; Component Kind: Standard; ComponentLink1Description: http://www.farnell.com/datasheets/1849611.pdf; ComponentLink1URL: http://www.farnell.com/datasheets/1849611.pdf; Library Name: SMD0603Res.IntLib; Manufacturer: MULTICOMP; Manufacturer Part Number: MCWR06X1101FTL; Packaging: Cut Tape; Pricing 1: 10=0.0035, 100=0.0021, 500=0.0015, 1000=0.0012, 2500=0.001 (GBP); Resistance: 1k1; Resistance Tolerance: ± 1%; Resistor Element Material: Thick Film; RoHS: Y-EX; Stock 1: 8493; Supplier 1: Farnell; Supplier Part Number 1: 2447274
R10 = CRCW06031M00FKEB: RES SMD 1M OHM 1% 1/10W 0603; Manufacturer: Vishay Dale; Power (Watts): 0.1W, 1/10W; Temperature Coefficient: ±100ppm/°C; Category: Resistors; Component Kind: Standard; ComponentLink1Description: http://www.vishay.com/docs/20035/dcrcwe3.pdf; ComponentLink1URL: http://www.vishay.com/docs/20035/dcrcwe3.pdf; Composition: Thick Film; Failure Rate: -; Features: Automotive AEC-Q200; Height - Seated (Max): 0.020" (0.50mm); Library Name: SMD0603Res.IntLib; Manufacturer Part Number: CRCW06031M00FKEB; Operating Temperature: -55°C ~ 155°C; Package / Case: 0603 (1608 Metric); Packaging: Tape & Reel (TR); Part Status: Active; Pricing 1: 20000=0.00432, 30000=0.00405 (USD); Resistance: 1M; RoHS: RoHS Compliant; Size / Dimension: 0.063" L x 0.033" W (1.60mm x 0.85mm); Stock 1: 0; Supplier 1: Mouser; Supplier 2: Farnell; Supplier 3: Digi-Key; Supplier Device Package: 0603; Supplier Part Number 1: 71-CRCW06031M00FKEB; Supplier Part Number 2: 1853280; Supplier Part Number 3: CRCW06031M00FKEB-ND; Tolerance: ±1%
U1 = SN74LVC86APWR: 4-ch, 2-input, 1.65-V to 3.6-V XOR (exclusive OR) gates 14-TSSOP -40 to 125; Manufacturer: Texas Instruments; High Level Output Current: -24mA; Low Level Output Current: 24mA; Max Supply Voltage: 3.6V; Min Supply Voltage: 1.65V; Number of Bits: 4; Number of Input Lines: 2; Number of Output Lines: 1; Propagation Delay: 4.4ns; Quiescent Current: 1uA; Schmitt Trigger Input: No; Turn-On Delay Time: 4.6ns; Case/Package: TSSOP; Category: Logic Gates; Component Kind: Standard; ComponentLink1Description: https://datasheet.ciiva.com/14290/sn54lvc86a-14290721.pdf?src-supplier=Avnet; ComponentLink1URL: https://datasheet.ciiva.com/14290/sn54lvc86a-14290721.pdf?src-supplier=Avnet; ComponentLink2Description: https://datasheet.ciiva.com/41455/001017231-da-01-en-ic-qu-2in-exc-sn74lvc86apwr-tssop-14-tid-41455867.pdf?src-supplier=Conrad; ComponentLink2URL: https://datasheet.ciiva.com/41455/001017231-da-01-en-ic-qu-2in-exc-sn74lvc86apwr-tssop-14-tid-41455867.pdf?src-supplier=Conrad; ComponentLink3Description: https://datasheet.ciiva.com/46439/1847506-46439206.pdf?src-supplier=Element14; ComponentLink3URL: https://datasheet.ciiva.com/46439/1847506-46439206.pdf?src-supplier=Element14; ComponentLink4Description: https://datasheet.ciiva.com/1865/sn74lvc86a-1865017.pdf?src-supplier=Digi-Key; ComponentLink4URL: https://datasheet.ciiva.com/1865/sn74lvc86a-1865017.pdf?src-supplier=Digi-Key; ComponentLink5Description: https://datasheet.ciiva.com/1865/sn74lvc86a-1865016.pdf?src-supplier=Digi-Key; ComponentLink5URL: https://datasheet.ciiva.com/1865/sn74lvc86a-1865016.pdf?src-supplier=Digi-Key; ComponentLink6Description: https://datasheet.ciiva.com/1865/sn74lvc86a-1865018.pdf?src-supplier=Digi-Key; ComponentLink6URL: https://datasheet.ciiva.com/1865/sn74lvc86a-1865018.pdf?src-supplier=Digi-Key; ComponentLink7Description: https://datasheet.ciiva.com/15221/getdatasheetpartid-247160-15221930.pdf?src-supplier=Verical; ComponentLink7URL: https://datasheet.ciiva.com/15221/getdatasheetpartid-247160-15221930.pdf?src-supplier=Verical; Contact Plating: Gold; Height: 1.15mm; Lead Free: Lead Free; Length: 5mm; Library Name: Logic IC.IntLib; Logic Function: XOR; Manufacturer Part Number: SN74LVC86APWR; Max Operating Temperature: 125°C; Min Operating Temperature: -40°C; Mount: Surface Mount; Number of Circuits: 4; Number of Elements: 4; Number of Gates: 4; Number of Inputs: 2; Number of Outputs: 1; Number of Pins: 14; Package Quantity: 1; Packaging: Tape and Reel; Pricing 1: 1=0.49000, 10=0.39400, 50=0.39400, 100=0.24300, 1000=0.15100, 10000=0.13800 (USD); Radiation Hardening: No; REACH SVHC: No SVHC; RoHS: Yes; RoHS Compliant: Yes; Stock 1: 443; Supplier 1: Mouser; Supplier 2: Farnell; Supplier 3: Digi-Key; Supplier 4: Arrow Electronics; Supplier Part Number 1: 595-SN74LVC86APWR; Supplier Part Number 2: 3120672; Supplier Part Number 3: 296-1242-1-ND; Supplier Part Number 4: SN74LVC86APWR; Weight: 0.002018oz; Width: 4.4mm
U2 = NE5532DR: 2-channel, 10-MHz, 26-V output swing, low-noise audio op amp 8-SOIC 0 to 70; Manufacturer: Texas Instruments; Bandwidth: 10MHz; Common Mode Rejection Ratio: 70dB; Dual Supply Voltage: 9V; Input Bias Current: 800nA; Input Offset Voltage (Vos): 4mV; Max Dual Supply Voltage: 15V; Min Dual Supply Voltage: 5V; Nominal Supply Current: 16mA; Number of Amplifiers: 2; Operating Supply Current: 8mA; Output Current: 38mA; Parameter 1: Jellybean; Slew Rate: 9V/µs; Voltage Gain: 100dB; Case/Package: D; Category: Amplifiers - Op Amps, Buffer, Instrumentation; Component Kind: Standard; ComponentLink1Description: https://datasheet.ciiva.com/1796/ne5532-1796190.pdf?src-supplier=Digi-Key; ComponentLink1URL: https://datasheet.ciiva.com/1796/ne5532-1796190.pdf?src-supplier=Digi-Key; ComponentLink2Description: https://datasheet.ciiva.com/1796/ne5532-1796189.pdf?src-supplier=Digi-Key; ComponentLink2URL: https://datasheet.ciiva.com/1796/ne5532-1796189.pdf?src-supplier=Digi-Key; ComponentLink3Description: https://datasheet.ciiva.com/1796/ne5532-1796191.pdf?src-supplier=Digi-Key; ComponentLink3URL: https://datasheet.ciiva.com/1796/ne5532-1796191.pdf?src-supplier=Digi-Key; Contact Plating: Gold; Gain Bandwidth Product: 10MHz; Height: 1.58mm; Lead Free: Lead Free; Length: 4.9mm; Library Name: Lib1.IntLib; Manufacturer Part Number: NE5532DR; Max I/O Voltage: 4mV; Max Operating Temperature: 70°C; Max Supply Voltage: 15V; Min Operating Temperature: 0°C; Nominal Gain Bandwidth Product: 10MHz; Number of Channels: 2; Number of Circuits: 2; Number of Elements: 2; Number of Pins: 8; Output Current per Channel: 38mA; Package Quantity: 1; Packaging: Tape and Reel; Pricing 1: 1=0.60000, 10=0.51400, 50=0.51400, 100=0.35600, 500=0.30100, 1000=0.23300, 2500=0.21100, 10000=0.21100 (USD); Radiation Hardening: No; REACH SVHC: No SVHC; RoHS: Yes; RoHS Compliant: Yes; Supplier 1: Mouser; Supplier 2: Farnell; Supplier 3: Digi-Key; Supplier Part Number 1: 595-NE5532DR; Supplier Part Number 2: 3117312; Supplier Part Number 3: 296-13622-6-ND; Unity Gain Bandwidth Product: 10MHz; Width: 3.91mm
U3 = LDK320M33R: IC REG LINEAR 200MA SOT23-5; Manufacturer: STMicroelectronics; Control Features: Enable; Output Configuration: Positive; Output Type: Fixed; Protection Features: Over Current, Over Temperature; PSRR: 88dB (120Hz); Voltage - Input (Max): 18V; Voltage - Output (Min/Fixed): 3.3V; Voltage Dropout (Max): 0.35V @ 200mA; Category: Integrated Circuits (ICs); Component Kind: Standard; ComponentLink1Description: http://www.st.com/content/ccc/resource/technical/document/datasheet/0e/0c/26/c9/a4/d4/4b/a1/DM00236470.pdf/files/DM00236470.pdf/jcr:content/translations/en.DM00236470.pdf; ComponentLink1URL: http://www.st.com/content/ccc/resource/technical/document/datasheet/0e/0c/26/c9/a4/d4/4b/a1/DM00236470.pdf/files/DM00236470.pdf/jcr:content/translations/en.DM00236470.pdf; Current - Output: 200mA; Library Name: Lib1.IntLib; Manufacturer Part Number: LDK320M33R; Mounting Type: Surface Mount; Operating Temperature: -40°C ~ 125°C (TJ); Package / Case: SC-74A, SOT-753; Packaging: Cut Tape (CT); Part Status: Active; Pricing 1: 1=0.85, 10=0.748, 100=0.5733, 500=0.4532, 1000=0.36256 (USD); RoHS: RoHS Compliant; Stock 1: 5592; Supplier 1: Mouser; Supplier 2: Farnell; Supplier 3: Digi-Key; Supplier Device Package: SOT-23-5; Supplier Part Number 1: 511-LDK320M33R; Supplier Part Number 2: 3130011; Supplier Part Number 3: 497-17034-1-ND
